# Altium schematic sheet Control_V2.SchDoc
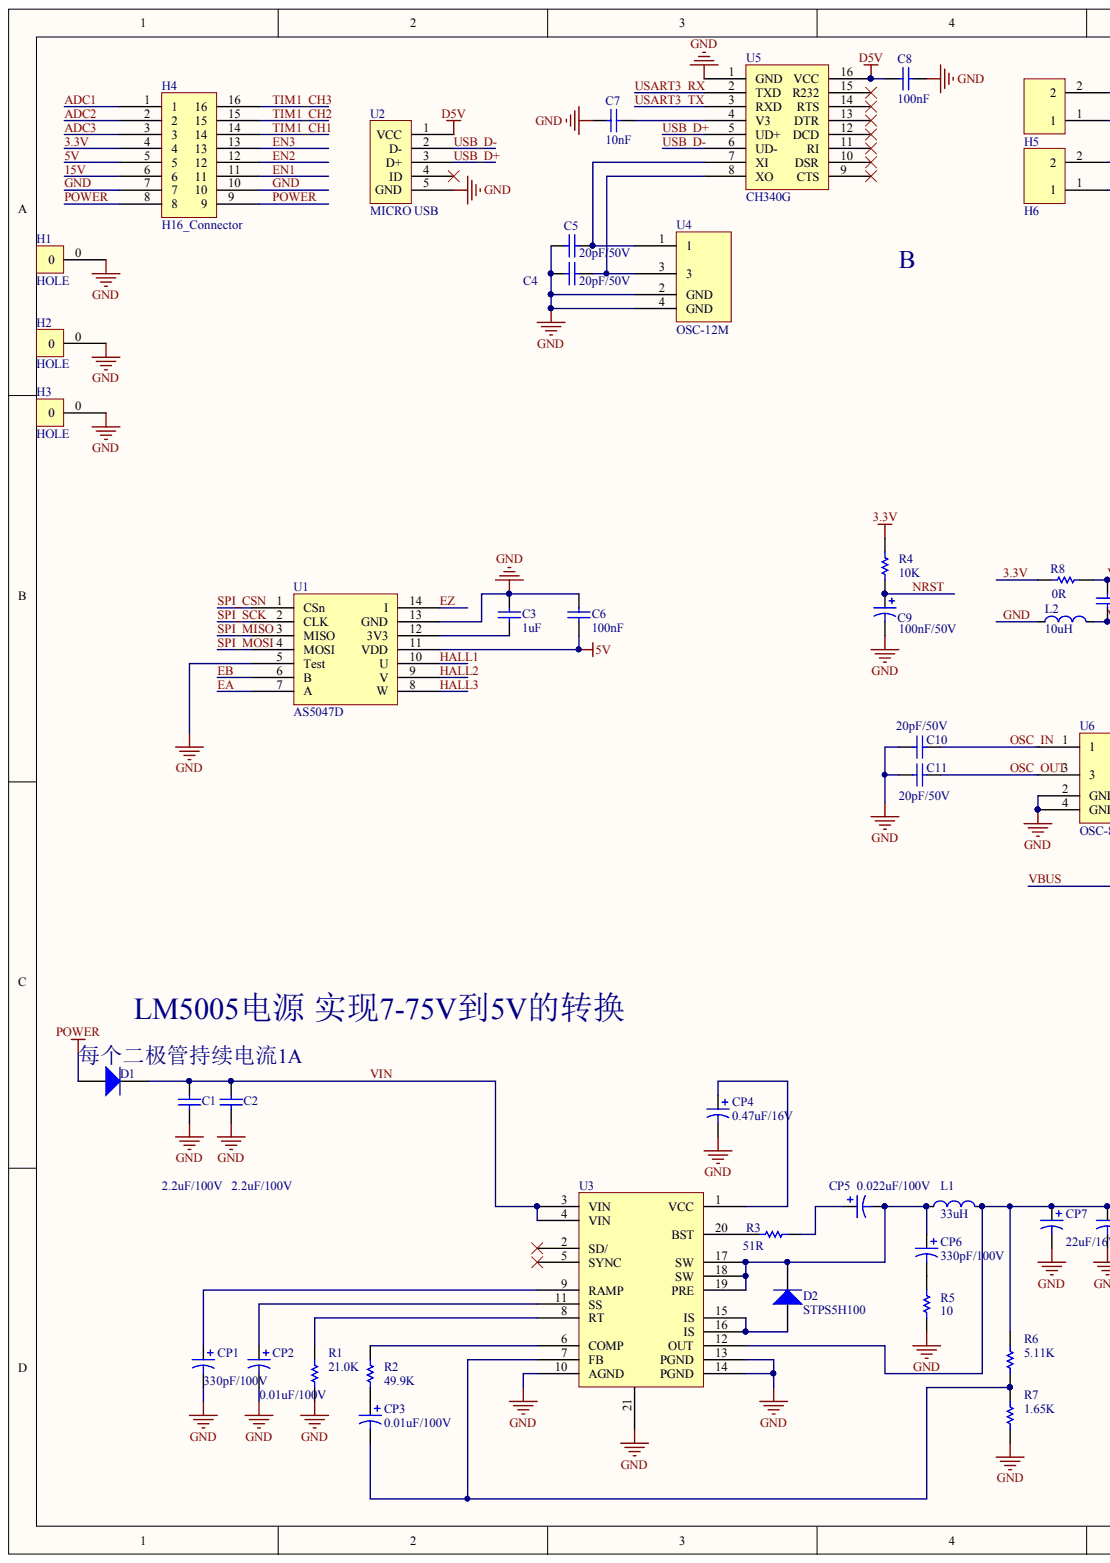
[diagram p0: Control_V2.SchDoc, left]
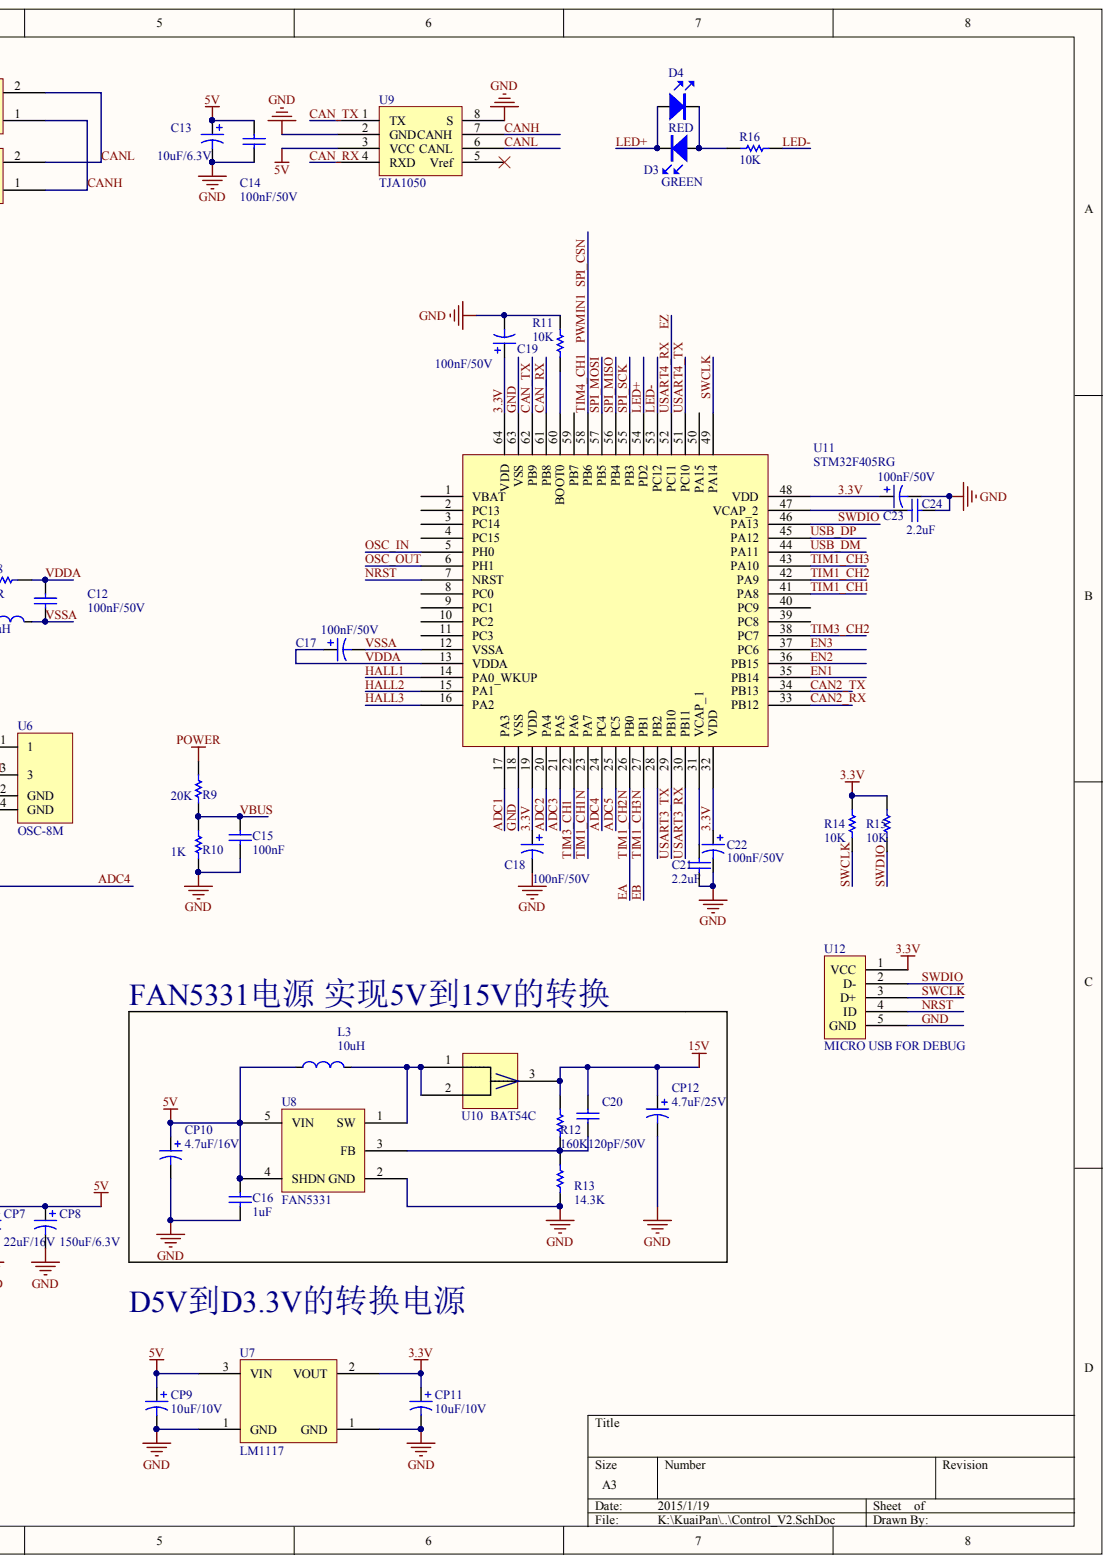
[diagram p1: Control_V2.SchDoc, right]

## Parts
C1  value 2.2uF/100V  (hidden comment: Cap)
C2  value 2.2uF/100V  (hidden comment: Cap)
C3  value 1uF  (hidden comment: Cap)
C4  value 20pF/50V  (hidden comment: Cap)
C5  value 20pF/50V  (hidden comment: Cap)
C6  value 100nF  (hidden comment: Cap)
C7  value 10nF  (hidden comment: Cap)
C8  value 100nF  (hidden comment: Cap)
C9  value 100nF/50V  (hidden comment: Cap Pol)
C10  value 20pF/50V  (hidden comment: Cap)
C11  value 20pF/50V  (hidden comment: Cap)
C12  value 100nF/50V  (hidden comment: Cap)
C13  value 10uF/6.3V  (hidden comment: Cap Pol)
C14  value 100nF/50V  (hidden comment: Cap)
C15  value 100nF  (hidden comment: Cap)
C16  value 1uF  (hidden comment: Cap)
C17  value 100nF/50V  (hidden comment: Cap Pol)
C18  value 100nF/50V  (hidden comment: Cap Pol)
C19  value 100nF/50V  (hidden comment: Cap Pol)
C20  value 120pF/50V  (hidden comment: Cap)
C21  value 2.2uF  (hidden comment: Cap)
C22  value 100nF/50V  (hidden comment: Cap Pol)
C23  value 100nF/50V  (hidden comment: Cap Pol)
C24  value 2.2uF  (hidden comment: Cap)
CP1  value 330pF/100V  (hidden comment: Cap Pol)
CP2  value 0.01uF/100V  (hidden comment: Cap Pol)
CP3  value 0.01uF/100V  (hidden comment: Cap Pol)
CP4  value 0.47uF/16V  (hidden comment: Cap Pol)
CP5  value 0.022uF/100V  (hidden comment: Cap Pol)
CP6  value 330pF/100V  (hidden comment: Cap Pol)
CP7  value 22uF/16V  (hidden comment: Cap Pol)
CP8  value 150uF/6.3V  (hidden comment: Cap Pol)
CP9  value 10uF/10V  (hidden comment: Cap Pol)
CP10  value 4.7uF/16V  (hidden comment: Cap Pol)
CP11  value 10uF/10V  (hidden comment: Cap Pol)
CP12  value 4.7uF/25V  (hidden comment: Cap Pol)
D1  Diode  (value not drawn)
D2  STPS5H100
D3  GREEN
D4  RED
H1  HOLE
H2  HOLE
H3  HOLE
H4  H16_Connector
H5  CAN  (value not drawn)
H6  CAN
L1  L  value 33uH
L2  value 10uH  (hidden comment: INDUCTOR)
L3  L  value 10uH
R1  value 21.0K  (hidden comment: RES)
R2  value 49.9K  (hidden comment: RES)
R3  value 51R  (hidden comment: RES)
R4  value 10K  (hidden comment: RES)
R5  value 10  (hidden comment: RES)
R6  value 5.11K  (hidden comment: RES)
R7  value 1.65K  (hidden comment: RES)
R8  value 0R  (hidden comment: RES)
R9  value 20K  (hidden comment: RES)
R10  value 1K  (hidden comment: RES)
R11  value 10K  (hidden comment: RES)
R12  value 160K  (hidden comment: RES)
R13  value 14.3K  (hidden comment: RES)
R14  value 10K  (hidden comment: RES)
R15  value 10K  (hidden comment: RES)
R16  value 10K  (hidden comment: RES)
U1  AS5047D
U2  MICRO USB
U3  LM5005  (value not drawn)
U4  OSC-12M
U5  CH340G
U6  OSC-8M
U7  LM1117
U8  FAN5331
U9  TJA1050
U10  BAT54C
U11  STM32F405RG
U12  MICRO USB FOR DEBUG  value MICRO USB

## Nets
D5V: C8.1 U2.1(VCC) U5.16(VCC)
3.3V: C18.1 C19.1 C22.1 C23.1 CP11.1 H4.4 R4.1 R8.2 R14.2 R15.2 U7.2 U11.19(VDD) U11.32(VDD) U11.48(VDD) U11.64(VDD) U12.1(VCC)
5V: C6.2 C13.1 C14.1 C16.2 CP7.1 CP8.1 CP9.1 CP10.1 H4.5 L1.2 L3.1 R6.2 U1.11(VDD) U3.12(OUT) U7.3 U8.4(SHDN) U8.5(VIN) U9.3(VCC)
15V: C20.1 CP12.1 H4.6 R12.1 U10.3
ADC1: H4.1 U11.17(PA3)
ADC2: H4.2 U11.20(PA4)
ADC3: H4.3 U11.21(PA5)
ADC4: C15.2 R9.1 R10.2 U11.24(PC4)
ADC5: U11.25(PC5)
CAN2_RX: U11.33(PB12)
CAN2_TX: U11.34(PB13)
CAN_RX: U9.4(RXD) U11.61(PB8)
CAN_TX: U9.1(TX) U11.62(PB9)
CANH: H5.1 H6.1 U9.7(CANH)
CANL: H5.2 H6.2 U9.6(CANL)
EA: U1.7(A) U11.26(PB0)
EB: U1.6(B) U11.27(PB1)
EN1: H4.11 U11.35(PB14)
EN2: H4.12 U11.36(PB15)
EN3: H4.13 U11.37(PC6)
EZ: U1.14(I) U11.52(PC11)
GND: C1.2 C2.2 C3.1 C4.1 C5.1 C6.1 C7.2 C8.2 C9.2 C10.2 C11.2 C13.2 C14.2 C15.1 C16.1 C18.2 C19.2 C21.1 C22.2 C23.2 C24.1 CP1.2 CP2.2 CP4.2 CP7.2 CP8.2 CP9.2 CP10.2 CP11.2 CP12.2 H1.0 H2.0 H3.0 H4.7 H4.10 L2.1 R1.1 R5.2 R7.1 R10.1 R11.2 R13.2 U1.5(Test) U1.13(GND) U2.5(GND) U3.10(AGND) U3.13(PGND) U3.14(PGND) U3.21 U4.2(GND) U4.4(GND) U5.1(GND) U6.2(GND) U6.4(GND) U7.1 U8.2(GND) U9.2(GND) U9.8(S) U11.18(VSS) U11.63(VSS) U12.5(GND)
HALL1: U1.10(U) U11.14(PA0_WKUP)
HALL2: U1.9(V) U11.15(PA1)
HALL3: U1.8(W) U11.16(PA2)
LED+: D3.2 D4.1 U11.54(PD2)
LED-: R16.1 U11.53(PC12)
NRST: C9.1 R4.2 U11.7(NRST) U12.4(ID)
OSC_IN: C10.1 U6.1 U11.5(PH0)
OSC_OUT: C11.1 U6.3 U11.6(PH1)
POWER: D1.1 H4.8 H4.9 R9.2
PWMIN1: U1.1(CSn) U11.58(PB6)
SPI_MISO: U1.3(MISO) U11.56(PB4)
SPI_MOSI: U1.4(MOSI) U11.57(PB5)
SPI_SCK: U1.2(CLK) U11.55(PB3)
SWCLK: R14.1 U11.49(PA14) U12.3(D+)
SWDIO: R15.1 U11.46(PA13) U12.2(D-)
TIM1_CH1: H4.14 U11.41(PA8)
TIM1_CH1N: U11.23(PA7)
TIM1_CH2: H4.15 U11.42(PA9)
TIM1_CH3: H4.16 U11.43(PA10)
TIM3_CH1: U11.22(PA6)
TIM3_CH2: U11.38(PC7)
USART3_RX: U5.2(TXD) U11.30(PB11)
USART3_TX: U5.3(RXD) U11.29(PB10)
USART4_TX: U11.51(PC10)
USB_D+: U2.3(D+) U5.5(UD+)
USB_D-: U2.2(D-) U5.6(UD-)
USB_DM: U11.44(PA11)
USB_DP: U11.45(PA12)
VDDA: C12.1 C17.1 R8.1 U11.13(VDDA)
VIN: C1.1 C2.1 D1.2 U3.3(VIN) U3.4(VIN)
VSSA: C12.2 C17.2 L2.2 U11.12(VSSA)
NetC3_2: C3.2 U1.12(3V3)
NetC4_2: C4.2 U4.3 U5.8(XO)
NetC5_2: C5.2 U4.1 U5.7(XI)
NetC7_1: C7.1 U5.4(V3)
NetC20_2: C20.2 R12.2 R13.1 U8.3(FB)
NetC21_2: C21.2 U11.31(VCAP_1)
NetC24_2: C24.2 U11.47(VCAP_2)
NetCP1_1: CP1.1 U3.9(RAMP)
NetCP2_1: CP2.1 U3.11(SS)
NetCP3_1: CP3.1 R2.2
NetCP3_2: CP3.2 R6.1 R7.2 U3.7(FB)
NetCP4_1: CP4.1 U3.1(VCC)
NetCP5_1: CP5.1 R3.2
NetCP5_2: CP5.2 CP6.1 D2.2 L1.1 U3.17(SW) U3.18(SW) U3.19(PRE)
NetCP6_2: CP6.2 R5.1
NetD2_1: D2.1 U3.15(IS) U3.16(IS)
NetD3_1: D3.1 D4.2 R16.2
NetL3_2: L3.2 U8.1(SW) U10.1 U10.2
NetR1_2: R1.2 U3.8(RT)
NetR2_1: R2.1 U3.6(COMP)
NetR3_1: R3.1 U3.20(BST)
NetR11_1: R11.1 U11.60(BOOT0)

Not connected: U2 4(ID); U3 2(SD/) 5(SYNC); U5 9(CTS) 10(DSR) 11(RI) 12(DCD) 13(DTR) 14(RTS) 15(R232); U9 5(Vref); U11 1(VBAT) 2(PC13) 3(PC14) 4(PC15) 8(PC0) 9(PC1) 10(PC2) 11(PC3) 28(PB2) 39(PC8) 40(PC9) 50(PA15) 59(PB7)

## Library data of the parts
D1 = (no symbol name): Value: 1A M7
D2 = (no symbol name): Value: 5A/100V
D3 = (no symbol name): Value: 绿色0603
D4 = (no symbol name): Value: 红色0603
H1-H3 = (no symbol name): Value: D2mm
H5 H6 = (no symbol name): Value: 3.81绿色2 PIN
U3 = (no symbol name): Value: 3A/75V
U4 = (no symbol name): Value: 12MHz
U6 = (no symbol name): Value: 8MHz5032
U7 = (no symbol name): Value: 5V-3.3V LM1117
U8 = (no symbol name): Value: 升压２０Ｖ　１Ａ
U10 = (no symbol name): Value: 30V/200mA
U11 = (no symbol name): Value: stm32f405rgt6
C1-C24 CP1-CP12 H4 L1-L3 R1-R16 U1 U2 U5 U9 U12 = (no symbol name)
  H4: Value: H16_Connector
  U1: Value: AS5047D
  U2: Value: MICRO USB
  U5: Value: CH340G
  U9: Value: TJA1050
